# Altium schematic sheet 9_arduino.SchDoc(9_arduino)
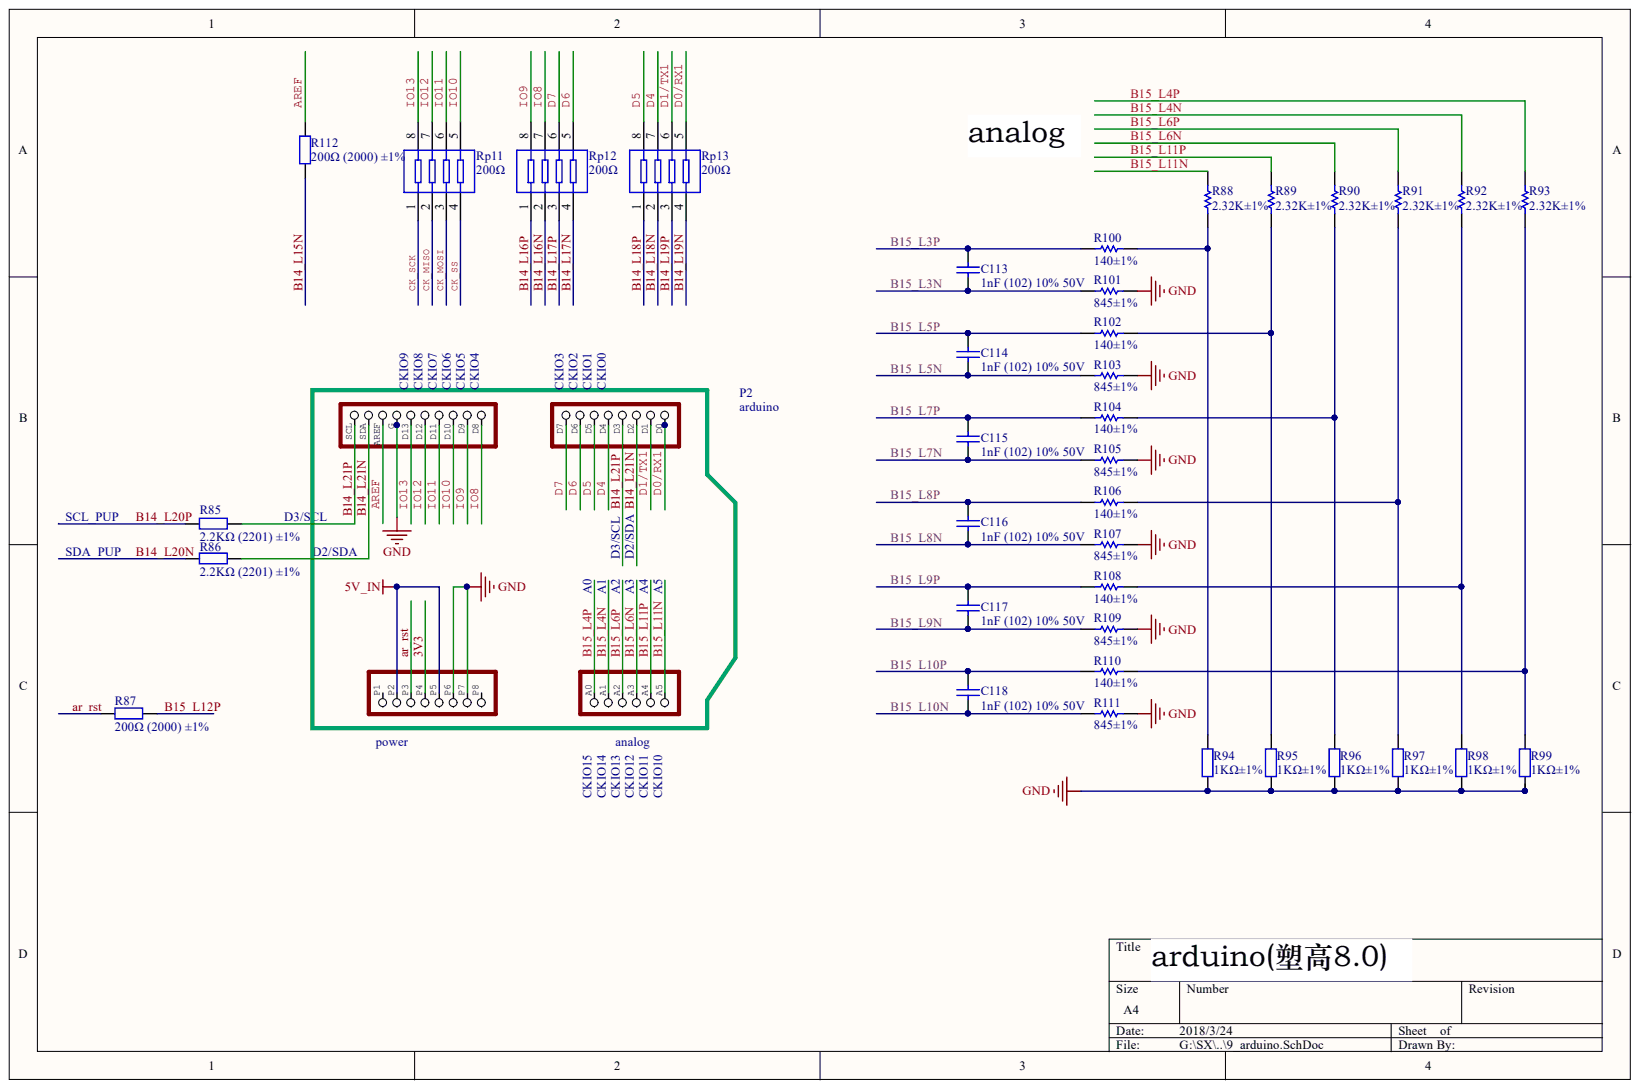
[diagram p0: 9_arduino.SchDoc]

## Parts
C113  1nF (102) 10% 50V  [0402_C]
C114  1nF (102) 10% 50V  [0402_C]
C115  1nF (102) 10% 50V  [0402_C]
C116  1nF (102) 10% 50V  [0402_C]
C117  1nF (102) 10% 50V  [0402_C]
C118  1nF (102) 10% 50V  [0402_C]
P2  arduino  [arduino]
R85  2.2KΩ (2201) ±1%  [0402_R]
R86  2.2KΩ (2201) ±1%  [0402_R]
R87  200Ω (2000) ±1%  [0402_R]
R88  2.32K±1%  [0402_R]
R89  2.32K±1%  [0402_R]
R90  2.32K±1%  [0402_R]
R91  2.32K±1%  [0402_R]
R92  2.32K±1%  [0402_R]
R93  2.32K±1%  [0402_R]
R94  1KΩ±1%  [0402_R]
R95  1KΩ±1%  [0402_R]
R96  1KΩ±1%  [0402_R]
R97  1KΩ±1%  [0402_R]
R98  1KΩ±1%  [0402_R]
R99  1KΩ±1%  [0402_R]
R100  140±1%  [0402_R]
R101  845±1%  [0402_R]
R102  140±1%  [0402_R]
R103  845±1%  [0402_R]
R104  140±1%  [0402_R]
R105  845±1%  [0402_R]
R106  140±1%  [0402_R]
R107  845±1%  [0402_R]
R108  140±1%  [0402_R]
R109  845±1%  [0402_R]
R110  140±1%  [0402_R]
R111  845±1%  [0402_R]
R112  200Ω (2000) ±1%  [0402_R]
Rp11  200Ω  [0402_Rx4]
Rp12  200Ω  [0402_Rx4]
Rp13  200Ω  [0402_Rx4]

## Nets
5V_IN: P2.P2 P2.P5
GND: P2.G P2.P6 P2.P7 R94.1 R95.1 R96.1 R97.1 R98.1 R99.1 R101.1 R103.1 R105.1 R107.1 R109.1 R111.1
3V3: P2.P4
AR_RST: P2.P3 R87.1
AREF: P2.AREF R112.2
B14_L15N: R112.1
B14_L16N: Rp12.2
B14_L16P: Rp12.1
B14_L17N: Rp12.4
B14_L17P: Rp12.3
B14_L18N: Rp13.2
B14_L18P: Rp13.1
B14_L19N: Rp13.4
B14_L19P: Rp13.3
B14_L20N: R86.2
B14_L20P: R85.2
B14_L21N: P2.D2 P2.SDA R86.1
B14_L21P: P2.D3 P2.SCL R85.1
B15_L3N: C113.1 R101.2
B15_L3P: C113.2 R100.2
B15_L4N: P2.A1 R92.2
B15_L4P: P2.A0 R93.2
B15_L5N: C114.1 R103.2
B15_L5P: C114.2 R102.2
B15_L6N: P2.A3 R90.2
B15_L6P: P2.A2 R91.2
B15_L7N: C115.1 R105.2
B15_L7P: C115.2 R104.2
B15_L8N: C116.1 R107.2
B15_L8P: C116.2 R106.2
B15_L9N: C117.1 R109.2
B15_L9P: C117.2 R108.2
B15_L10N: C118.1 R111.2
B15_L10P: C118.2 R110.2
B15_L11N: P2.A5
B15_L11P: P2.A4 R89.2
B15_L12P: R87.2
CK_MISO: Rp11.2
CK_MOSI: Rp11.3
CK_SCK: Rp11.1
CK_SS: Rp11.4
D0/RX1: P2.D0 Rp13.5
D1/TX1: P2.D1 Rp13.6
D4: P2.D4 Rp13.7
D5: P2.D5 Rp13.8
D6: P2.D6 Rp12.5
D7: P2.D7 Rp12.6
IO8: P2.D8 Rp12.7
IO9: P2.D9 Rp12.8
IO10: P2.D10 Rp11.5
IO11: P2.D11 Rp11.6
IO12: P2.D12 Rp11.7
IO13: P2.D13 Rp11.8
NetR88_1: R88.1 R94.2 R100.1
NetR89_1: R89.1 R95.2 R102.1
NetR90_1: R90.1 R96.2 R104.1
NetR91_1: R91.1 R97.2 R106.1
NetR92_1: R92.1 R98.2 R108.1
NetR93_1: R93.1 R99.2 R110.1

Not connected: P2 P1 P8; R88 2

## Library data of the parts
C113-C118 = 0402 1nF (102) 10% 50V: 贴片电容; Component Kind: Standard; ComponentLink1Description: 供应商链接; ComponentLink1URL: http://www.szlcsc.com/product/details_1875.html; Library Name: Miscellaneous Devices LC.IntLib; Package: 0402; Supplier: LC; SuppliersPartNumber: C1523
R85 R86 = 0402 2.2KΩ (2201) 1%: 贴片电阻; Component Kind: Standard; ComponentLink1Description: 供应商链接; ComponentLink1URL: http://www.szlcsc.com/product/details_26622.html; Library Name: Miscellaneous Devices LC.IntLib; Package: 0402; Supplier: LC; SuppliersPartNumber: C25879
R87 R112 = 0402 200Ω (2000) 1%: 贴片电阻; Component Kind: Standard; ComponentLink1Description: 供应商链接; ComponentLink1URL: http://www.szlcsc.com/product/details_25830.html; Library Name: Miscellaneous Devices LC.IntLib; Package: 0402; Supplier: LC; SuppliersPartNumber: C25087
R88-R93 R100-R111 = Res1: Resistor; Component Kind: Standard; LatestRevisionDate: 17-Jul-2002; LatestRevisionNote: Re-released for DXP Platform.; Library Name: Miscellaneous Devices.IntLib; PackageDescription: Axial Device, Thru-Hole; 2 Leads; 0.3 in Pin Spacing; PackageReference: AXIAL-0.3; Published: 8-Jun-2000; Simulation: RESISTOR; Publisher: Altium Limited
  R88-R93: Value: 2.32K±1%
  R100 R102 R104 R106 R108 R110: Value: 140±1%
  R101 R103 R105 R107 R109 R111: Value: 845±1%
R94-R99 = 0402 1KΩ (1001) 1%: 贴片电阻; Component Kind: Standard; ComponentLink1Description: 供应商链接; ComponentLink1URL: http://www.szlcsc.com/product/details_12256.html; Library Name: Miscellaneous Devices LC.IntLib; Package: 0402; Supplier: LC; SuppliersPartNumber: C11702
Rp11-Rp13 = 0402*4 33Ω (330) 5%: 贴片排阻; Component Kind: Standard; ComponentLink1Description: 供应商链接; ComponentLink1URL: http://www.szlcsc.com/product/details_26244.html; Library Name: Miscellaneous Devices LC.IntLib; Package: 0402*4; Supplier: LC; SuppliersPartNumber: C25501
P2 = arduino: Component Kind: Standard; Library Name: Personal_Accumulation_Sch.SchLib
